# Altium schematic sheet DRL.SchDoc(DRL)
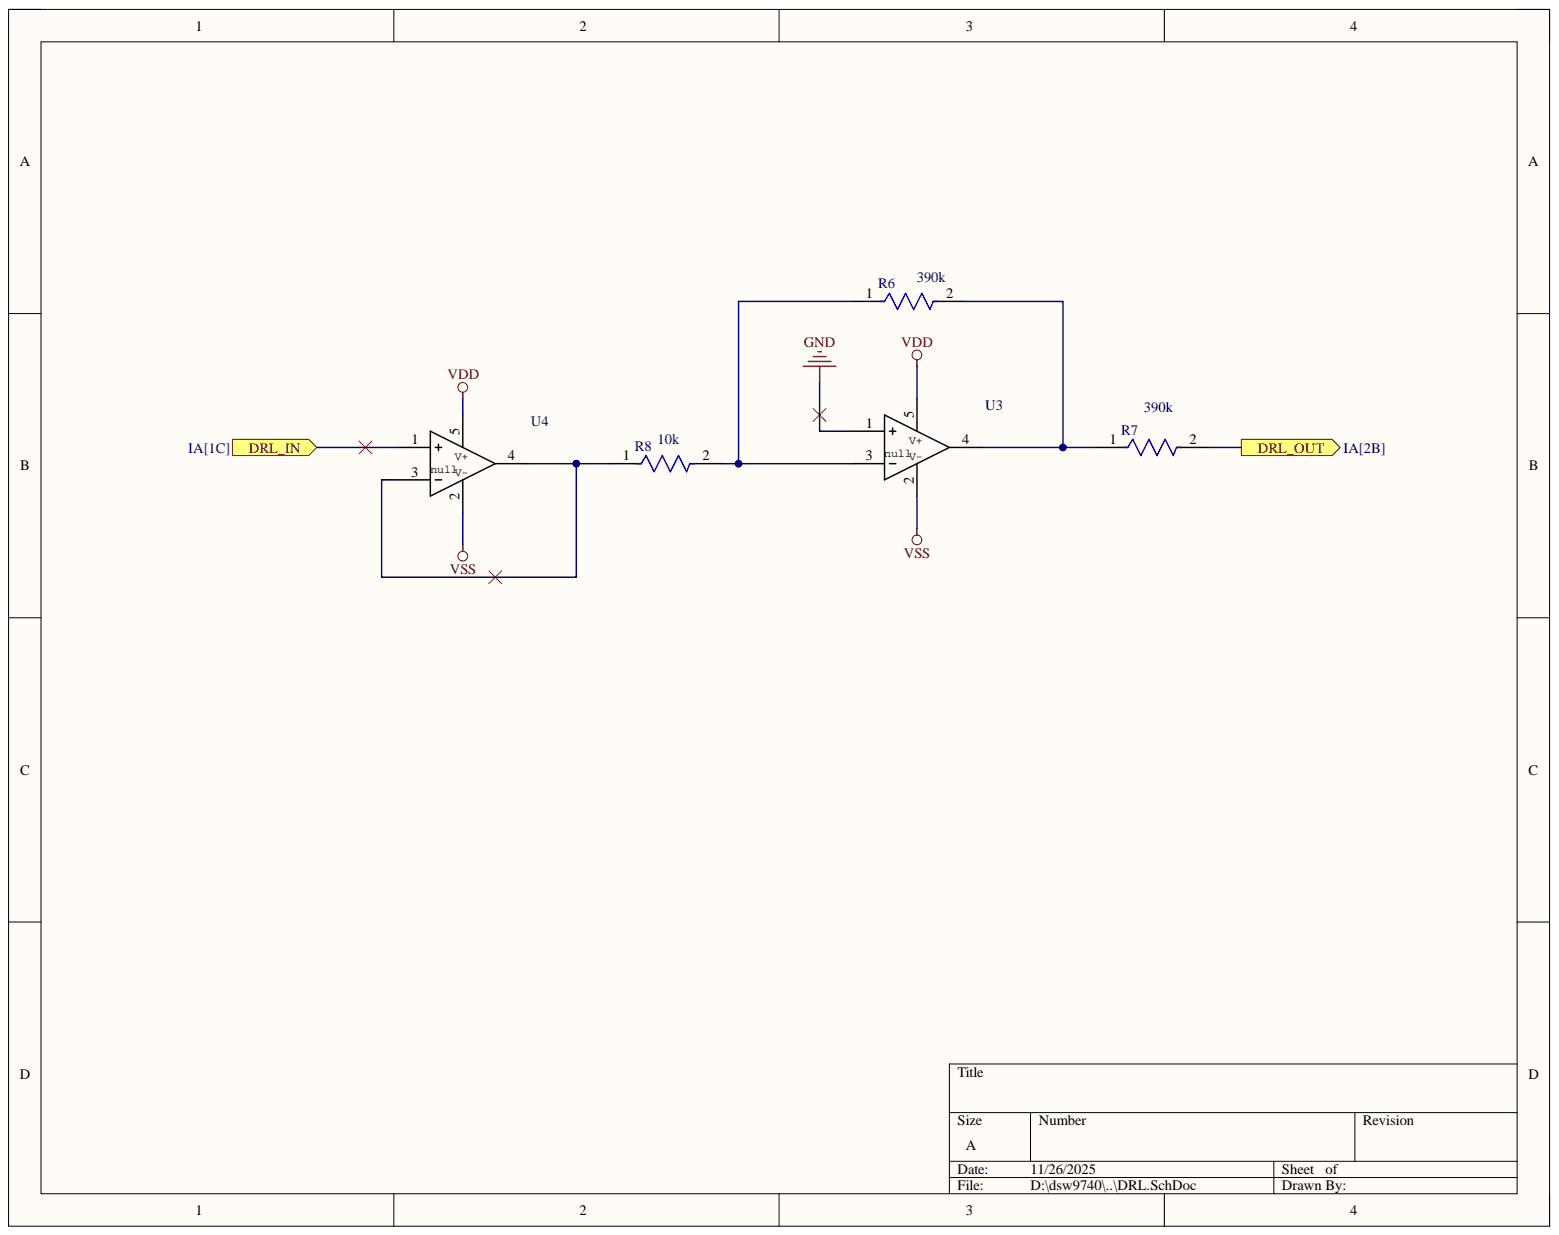
[diagram p0: DRL.SchDoc]

## Parts
R6  CRCW080516R0FKEA  [FP-CRCW0805-e3-MFG]  (value not drawn)
R7  CRCW080516R0FKEA  [FP-CRCW0805-e3-MFG]  (value not drawn)
R8  CRCW080516R0FKEA  [FP-CRCW0805-e3-MFG]  (value not drawn)
U3  TLV9041IDCKR  [DCK0005A-IPC_A]  (value not drawn)
U4  TLV9041IDCKR  [DCK0005A-IPC_A]  (value not drawn)

## Ports
DRL_IN, DRL_OUT

## Nets
GND: U3.1
VDD: U3.5(V+) U4.5(V+)
VSS: U3.2(V-) U4.2(V-)
DRL_IN: U4.1 ; port DRL_IN
DRL_OUT: R7.2 ; port DRL_OUT
NetR6_1: R6.1 R8.2 U3.3
NetR6_2: R6.2 R7.1 U3.4
NetR8_1: R8.1 U4.3 U4.4

## Library data of the parts
R6-R8 = CMP-02407-002795-1: RES Thick Film, 16Ω, 1%, 0.125W, 100ppm/°C, 0805; Max Power Dissipation: 125mW; Resistance: 16R; Voltage Rating: 150V; Case Code (Imperial): 0805; Case Code (Metric): 2012; Case/Package: 0805; Component Kind: Standard; Composition: Thick Film; Contact Plating: Tin; Depth: 1.25mm; Features: Automotive AEC-Q200; Height: 0.02inch; Lead Free: Lead Free; Length: 2mm; Library Name: Altium Content Vault; Max Operating Temperature: 155°C; Military Standard: Not; Min Operating Temperature: -55°C; Number of Pins: 2; Number of Terminations: 2; Package Quantity: 10; Packaging: Tape and Reel; Power Rating: 125mW; Radiation Hardening: No; Temperature Coefficient: 100ppm/°C; Termination: SMD/SMT; Tolerance: 1%; Voltage Rating (DC): 150V; Weight: 0.000194oz; Width: 0.049inch
U3 U4 = TLV9041IDCKR: Single, 5.5-V, 350-kHz, ultra-low 1.2-V, low-quiescent current (10-Î¼A) op amp 5-SC70 -40 to 125; Manufacturer_Name: Texas Instruments; Component Kind: Standard; Library Name: TLV9041IDCKR.SchLib; Manufacturer_Part_Number: TLV9041IDCKR; RefDes: RefDes; TYPE: TYPE; Copyright: Copyright (C) 2025 Ultra Librarian. All rights reserved.
